# Altium schematic sheet 2008_SablierElectronique_Commande_Matrice.SchDoc
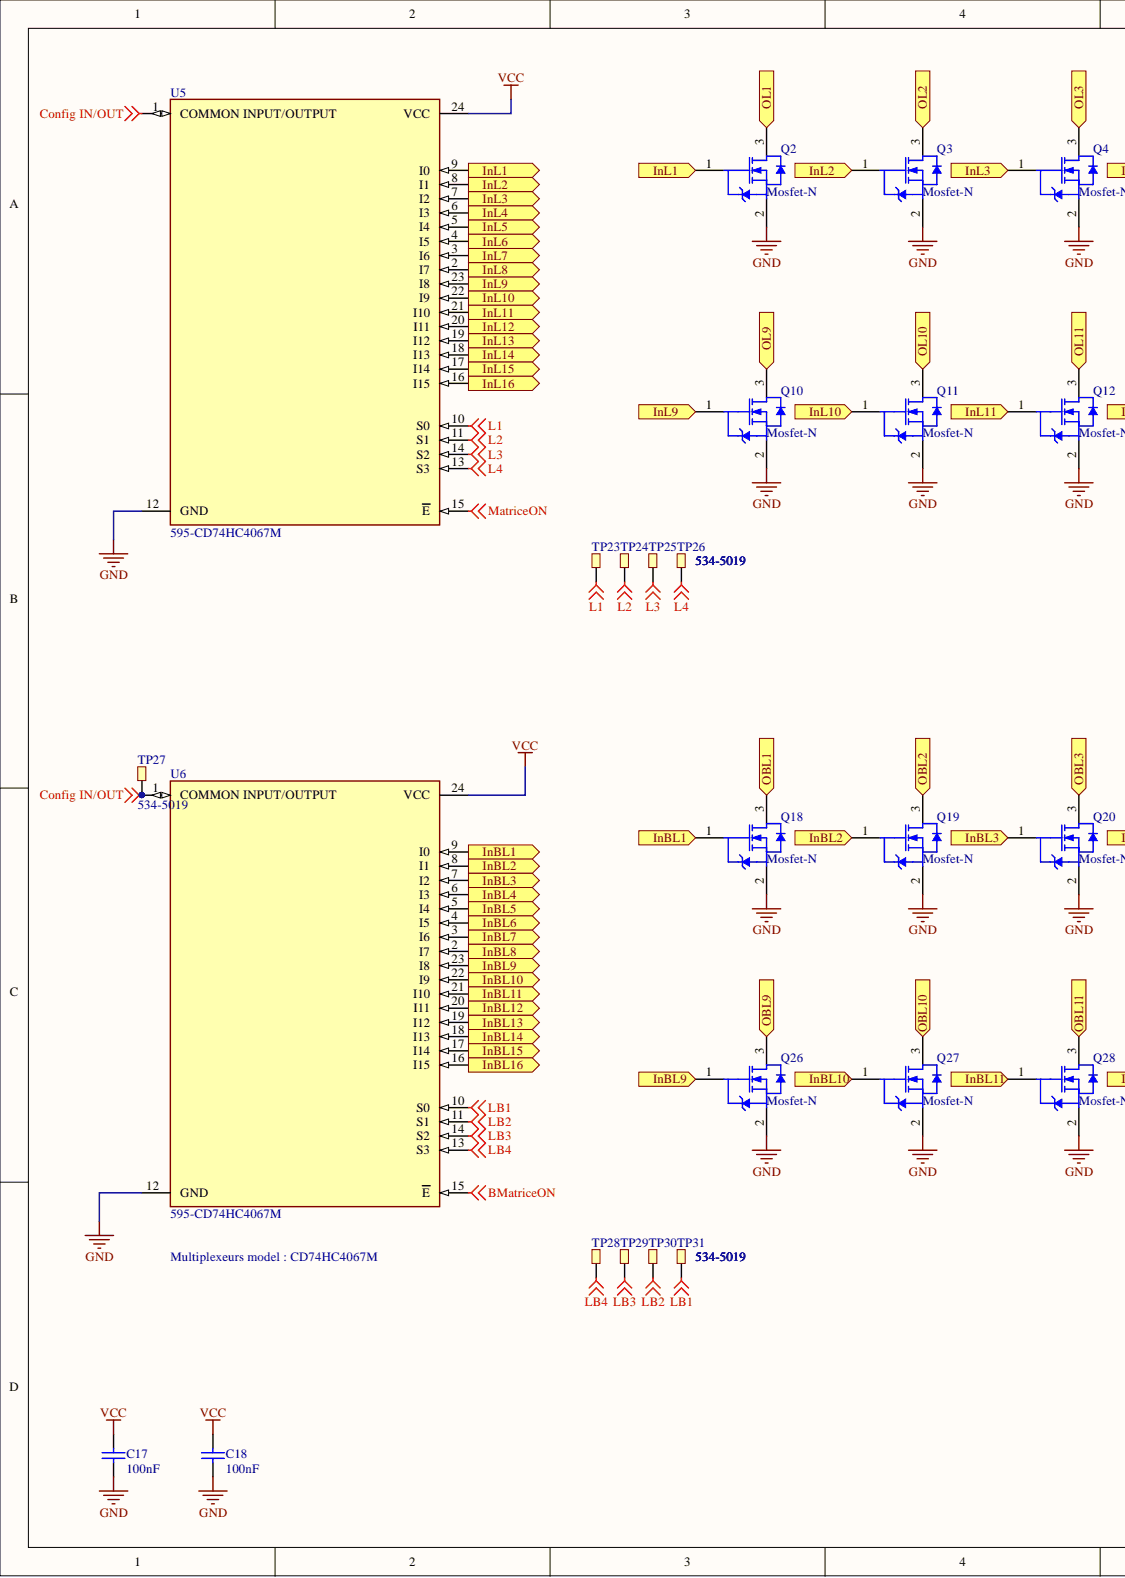
[diagram p0: 2008_SablierElectronique_Commande_Matrice.SchDoc, left]
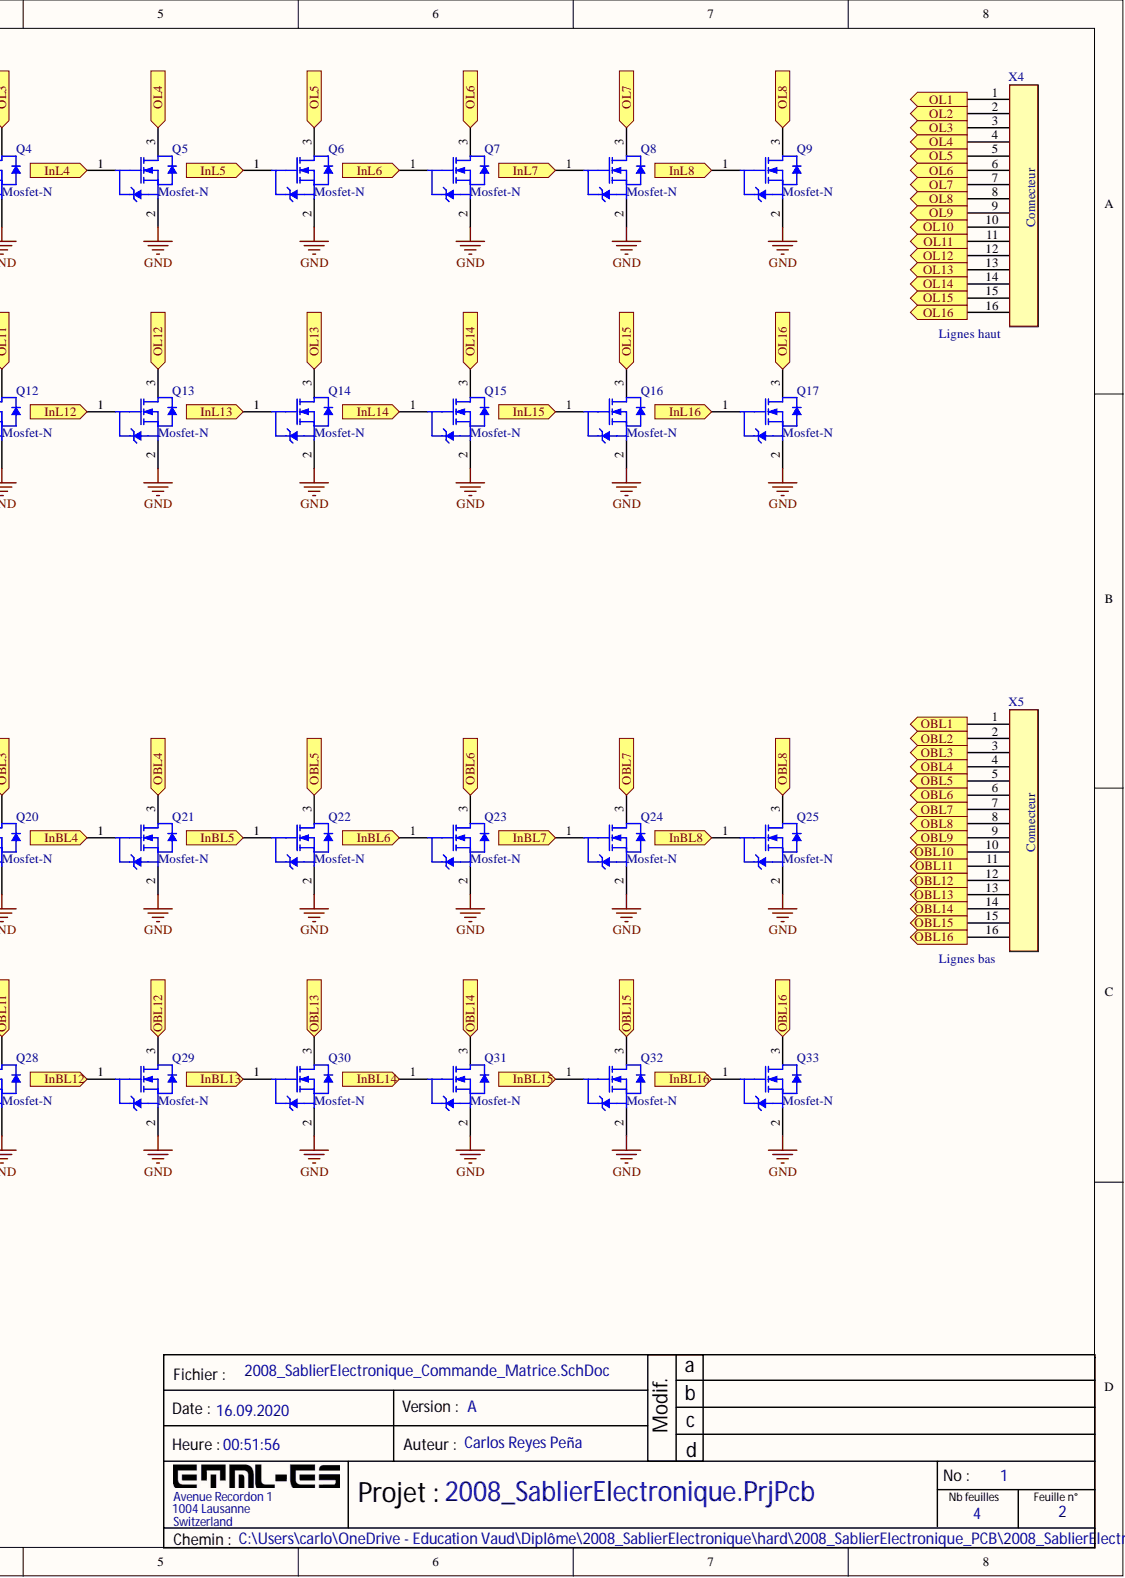
[diagram p1: 2008_SablierElectronique_Commande_Matrice.SchDoc, right]

## Parts
C17  100nF  [RESC0805(2012)_L]
C18  100nF  [RESC0805(2012)_L]
Q2  Mosfet-N  [FAIR-SOT23-3_V]
Q3  Mosfet-N  [FAIR-SOT23-3_V]
Q4  Mosfet-N  [FAIR-SOT23-3_V]
Q5  Mosfet-N  [FAIR-SOT23-3_V]
Q6  Mosfet-N  [FAIR-SOT23-3_V]
Q7  Mosfet-N  [FAIR-SOT23-3_V]
Q8  Mosfet-N  [FAIR-SOT23-3_V]
Q9  Mosfet-N  [FAIR-SOT23-3_V]
Q10  Mosfet-N  [FAIR-SOT23-3_V]
Q11  Mosfet-N  [FAIR-SOT23-3_V]
Q12  Mosfet-N  [FAIR-SOT23-3_V]
Q13  Mosfet-N  [FAIR-SOT23-3_V]
Q14  Mosfet-N  [FAIR-SOT23-3_V]
Q15  Mosfet-N  [FAIR-SOT23-3_V]
Q16  Mosfet-N  [FAIR-SOT23-3_V]
Q17  Mosfet-N  [FAIR-SOT23-3_V]
Q18  Mosfet-N  [FAIR-SOT23-3_V]
Q19  Mosfet-N  [FAIR-SOT23-3_V]
Q20  Mosfet-N  [FAIR-SOT23-3_V]
Q21  Mosfet-N  [FAIR-SOT23-3_V]
Q22  Mosfet-N  [FAIR-SOT23-3_V]
Q23  Mosfet-N  [FAIR-SOT23-3_V]
Q24  Mosfet-N  [FAIR-SOT23-3_V]
Q25  Mosfet-N  [FAIR-SOT23-3_V]
Q26  Mosfet-N  [FAIR-SOT23-3_V]
Q27  Mosfet-N  [FAIR-SOT23-3_V]
Q28  Mosfet-N  [FAIR-SOT23-3_V]
Q29  Mosfet-N  [FAIR-SOT23-3_V]
Q30  Mosfet-N  [FAIR-SOT23-3_V]
Q31  Mosfet-N  [FAIR-SOT23-3_V]
Q32  Mosfet-N  [FAIR-SOT23-3_V]
Q33  Mosfet-N  [FAIR-SOT23-3_V]
TP23  5019  [TESTPOINT_SMD]  (value not drawn)
TP24  5019  [TESTPOINT_SMD]  (value not drawn)
TP25  5019  [TESTPOINT_SMD]  (value not drawn)
TP26  5019  Supplier Part Number 1: 534-5019  [TESTPOINT_SMD]
TP27  5019  Supplier Part Number 1: 534-5019  [TESTPOINT_SMD]
TP28  5019  [TESTPOINT_SMD]  (value not drawn)
TP29  5019  [TESTPOINT_SMD]  (value not drawn)
TP30  5019  [TESTPOINT_SMD]  (value not drawn)
TP31  5019  Supplier Part Number 1: 534-5019  [TESTPOINT_SMD]
U5  Supplier Part Number 1: 595-CD74HC4067M  [SOIC24]  (hidden comment: CD74HCT4067)
U6  Supplier Part Number 1: 595-CD74HC4067M  [SOIC24]  (hidden comment: CD74HCT4067)
X4  Lignes haut  [CON8x8(edited)]
X5  Lignes bas  [CON8x8(edited)]

## Ports
InBL1, InBL2, InBL3, InBL4, InBL5, InBL6, InBL7, InBL8, InBL9, InBL10, InBL11, InBL12, InBL13, InBL14, InBL15, InBL16, InL1, InL2, InL3, InL4, InL5, InL6, InL7, InL8, InL9, InL10, InL11, InL12, InL13, InL14, InL15, InL16, OBL1, OBL2, OBL3, OBL4, OBL5, OBL6, OBL7, OBL8, OBL9, OBL10, OBL11, OBL12, OBL13, OBL14, OBL15, OBL16, OL1, OL2, OL3, OL4, OL5, OL6, OL7, OL8, OL9, OL10, OL11, OL12, OL13, OL14, OL15, OL16

## Nets
BMatriceON: U6.15(E)
Config IN/OUT: TP27.1 U5.1(COMMON_INPUT/OUTPUT) U6.1(COMMON_INPUT/OUTPUT)
GND: C17.1 C18.1 Q2.2 Q3.2 Q4.2 Q5.2 Q6.2 Q7.2 Q8.2 Q9.2 Q10.2 Q11.2 Q12.2 Q13.2 Q14.2 Q15.2 Q16.2 Q17.2 Q18.2 Q19.2 Q20.2 Q21.2 Q22.2 Q23.2 Q24.2 Q25.2 Q26.2 Q27.2 Q28.2 Q29.2 Q30.2 Q31.2 Q32.2 Q33.2 U5.12(GND) U6.12(GND)
L1: TP23.1 U5.10(S0)
L2: TP24.1 U5.11(S1)
L3: TP25.1 U5.14(S2)
L4: TP26.1 U5.13(S3)
LB1: TP31.1 U6.10(S0)
LB2: TP30.1 U6.11(S1)
LB3: TP29.1 U6.14(S2)
LB4: TP28.1 U6.13(S3)
MatriceON: U5.15(E)
VCC: C17.2 C18.2 U5.24(VCC) U6.24(VCC)
InBL1: Q18.1 U6.9(I0) ; port InBL1
InBL2: Q19.1 U6.8(I1) ; port InBL2
InBL3: Q20.1 U6.7(I2) ; port InBL3
InBL4: Q21.1 U6.6(I3) ; port InBL4
InBL5: Q22.1 U6.5(I4) ; port InBL5
InBL6: Q23.1 U6.4(I5) ; port InBL6
InBL7: Q24.1 U6.3(I6) ; port InBL7
InBL8: Q25.1 U6.2(I7) ; port InBL8
InBL9: Q26.1 U6.23(I8) ; port InBL9
InBL10: Q27.1 U6.22(I9) ; port InBL10
InBL11: Q28.1 U6.21(I10) ; port InBL11
InBL12: Q29.1 U6.20(I11) ; port InBL12
InBL13: Q30.1 U6.19(I12) ; port InBL13
InBL14: Q31.1 U6.18(I13) ; port InBL14
InBL15: Q32.1 U6.17(I14) ; port InBL15
InBL16: Q33.1 U6.16(I15) ; port InBL16
InL1: Q2.1 U5.9(I0) ; port InL1
InL2: Q3.1 U5.8(I1) ; port InL2
InL3: Q4.1 U5.7(I2) ; port InL3
InL4: Q5.1 U5.6(I3) ; port InL4
InL5: Q6.1 U5.5(I4) ; port InL5
InL6: Q7.1 U5.4(I5) ; port InL6
InL7: Q8.1 U5.3(I6) ; port InL7
InL8: Q9.1 U5.2(I7) ; port InL8
InL9: Q10.1 U5.23(I8) ; port InL9
InL10: Q11.1 U5.22(I9) ; port InL10
InL11: Q12.1 U5.21(I10) ; port InL11
InL12: Q13.1 U5.20(I11) ; port InL12
InL13: Q14.1 U5.19(I12) ; port InL13
InL14: Q15.1 U5.18(I13) ; port InL14
InL15: Q16.1 U5.17(I14) ; port InL15
InL16: Q17.1 U5.16(I15) ; port InL16
OBL1: Q18.3 X5.1 ; port OBL1
OBL2: Q19.3 X5.2 ; port OBL2
OBL3: Q20.3 X5.3 ; port OBL3
OBL4: Q21.3 X5.4 ; port OBL4
OBL5: Q22.3 X5.5 ; port OBL5
OBL6: Q23.3 X5.6 ; port OBL6
OBL7: Q24.3 X5.7 ; port OBL7
OBL8: Q25.3 X5.8 ; port OBL8
OBL9: Q26.3 X5.9 ; port OBL9
OBL10: Q27.3 X5.10 ; port OBL10
OBL11: Q28.3 X5.11 ; port OBL11
OBL12: Q29.3 X5.12 ; port OBL12
OBL13: Q30.3 X5.13 ; port OBL13
OBL14: Q31.3 X5.14 ; port OBL14
OBL15: Q32.3 X5.15 ; port OBL15
OBL16: Q33.3 X5.16 ; port OBL16
OL1: Q2.3 X4.1 ; port OL1
OL2: Q3.3 X4.2 ; port OL2
OL3: Q4.3 X4.3 ; port OL3
OL4: Q5.3 X4.4 ; port OL4
OL5: Q6.3 X4.5 ; port OL5
OL6: Q7.3 X4.6 ; port OL6
OL7: Q8.3 X4.7 ; port OL7
OL8: Q9.3 X4.8 ; port OL8
OL9: Q10.3 X4.9 ; port OL9
OL10: Q11.3 X4.10 ; port OL10
OL11: Q12.3 X4.11 ; port OL11
OL12: Q13.3 X4.12 ; port OL12
OL13: Q14.3 X4.13 ; port OL13
OL14: Q15.3 X4.14 ; port OL14
OL15: Q16.3 X4.15 ; port OL15
OL16: Q17.3 X4.16 ; port OL16

## Library data of the parts
C17 C18 = CAP1206: Component Kind: Standard; Library Name: 2008_SablierElectronique_SCHLIB.SchLib
Q2-Q33 = NEMOS-GSD-3_D: Component Kind: Standard; Library Name: 2008_SablierElectronique_SCHLIB.SchLib
TP23-TP31 = TESTPOINT: Test point smd; Component Kind: Standard; Supplier 1: Mouser; Library Name: 2008_SablierElectronique_SCHLIB.SchLib
  TP23-TP25 TP28-TP30: Supplier Part Number 1: 534-5019
X4 X5 = Mâle DIN 8 x 8: Component Kind: Standard; Library Name: 2008_SablierElectronique_SCHLIB.SchLib
U5 U6 = CD74HCT4067: Component Kind: Standard; Supplier 1: Mouser; Library Name: 2008_SablierElectronique_SCHLIB.SchLib
